# Altium schematic sheet Microcontroller.SchDoc(Microcontroller)
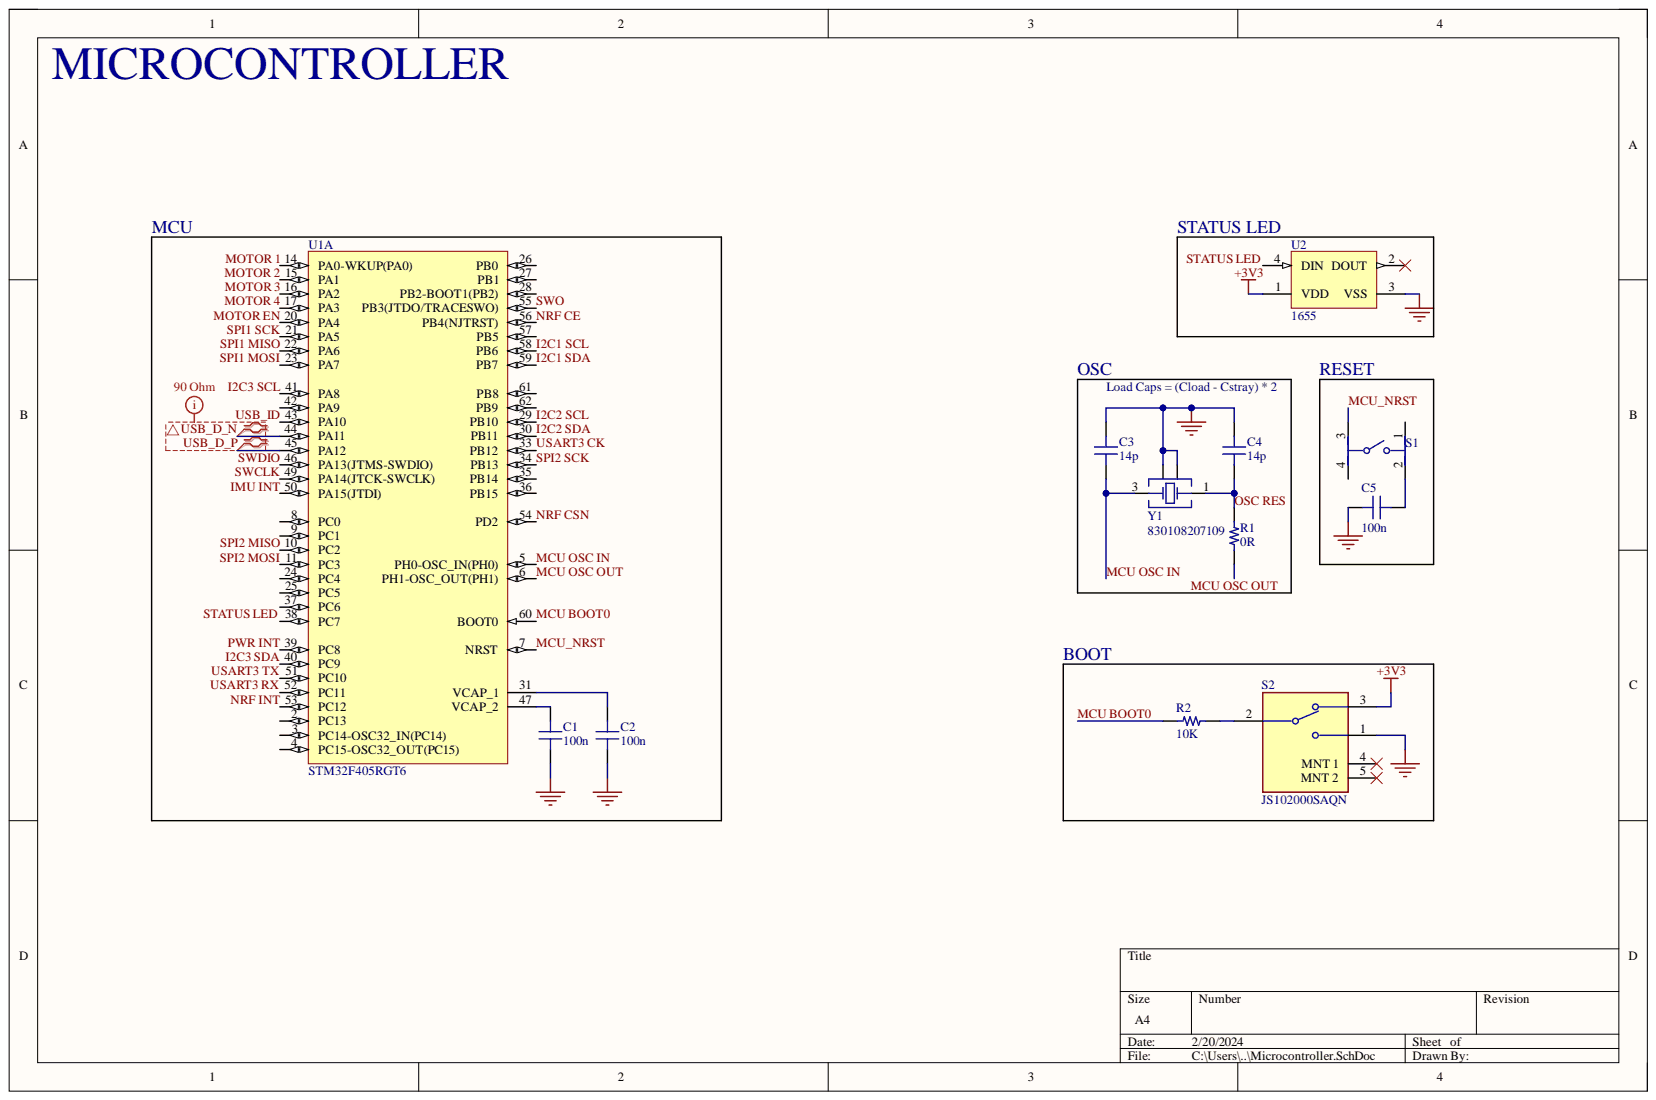
[diagram p0: Microcontroller.SchDoc]

## Parts
C1  100n  [CAP 0402_1005]
C2  100n  [CAP 0402_1005]
C3  14p  [CAP 0402_1005]
C4  14p  [CAP 0402_1005]
C5  100n  [CAP 0402_1005]
R1  0R  [RES 0402_1005]
R2  10K  [RES 0402_1005]
S1  PTS645SM43SMTR92LFS  [FP-PTS645SM43SMTR92LFS-MFG]  (value not drawn)
S2  JS102000SAQN  [FP-JS102000SAQN-MFG]
U1  STM32F405RGT6  [STM-LQFP64_M]  (part A)
U2  1655  [ADA-RGB-1655_V]
Y1  830108207109  [WE-XTAL_CFPX-180]

## Nets
+3V3: S2.3 U2.1(VDD)
GND: C1.1 C2.1 C3.2 C4.2 C5.2 S2.1 U2.3(VSS) Y1.2 Y1.4
I2C1 SCL: U1.58(PB6)
I2C1 SDA: U1.59(PB7)
I2C2 SCL: U1.29(PB10)
I2C2 SDA: U1.30(PB11)
I2C3 SCL: U1.41(PA8)
I2C3 SDA: U1.40(PC9)
IMU INT: U1.50(PA15(JTDI))
MCU BOOT0: R2.1 U1.60(BOOT0)
MCU OSC IN: C3.1 U1.5(PH0-OSC_IN(PH0)) Y1.3
MCU OSC OUT: R1.1 U1.6(PH1-OSC_OUT(PH1))
MCU_NRST: S1.3 U1.7(NRST)
MOTOR 1: U1.14(PA0-WKUP(PA0))
MOTOR 2: U1.15(PA1)
MOTOR 3: U1.16(PA2)
MOTOR 4: U1.17(PA3)
MOTOR EN: U1.20(PA4)
NRF CE: U1.56(PB4(NJTRST))
NRF CSN: U1.54(PD2)
NRF INT: U1.53(PC12)
OSC RES: C4.1 R1.2 Y1.1
PWR INT: U1.39(PC8)
SPI1 MISO: U1.22(PA6)
SPI1 MOSI: U1.23(PA7)
SPI1 SCK: U1.21(PA5)
SPI2 MISO: U1.10(PC2)
SPI2 MOSI: U1.11(PC3)
SPI2 SCK: U1.34(PB13)
STATUS LED: U1.38(PC7) U2.4(DIN)
SWCLK: U1.49(PA14(JTCK-SWCLK))
SWDIO: U1.46(PA13(JTMS-SWDIO))
SWO: U1.55(PB3(JTDO/TRACESWO))
USART3 CK: U1.33(PB12)
USART3 RX: U1.52(PC11)
USART3 TX: U1.51(PC10)
USB_D_N: U1.44(PA11)
USB_D_P: U1.45(PA12)
USB_ID: U1.43(PA10)
NetC1_2: C1.2 U1.47(VCAP_2)
NetC2_2: C2.2 U1.31(VCAP_1)
NetC5_1: C5.1 S1.2
NetR2_2: R2.2 S2.2

Not connected: S1 1 4; S2 4(MNT_1) 5(MNT_2); U1 2(PC13) 3(PC14-OSC32_IN(PC14)) 4(PC15-OSC32_OUT(PC15)) 8(PC0) 9(PC1) 24(PC4) 25(PC5) 26(PB0) 27(PB1) 28(PB2-BOOT1(PB2)) 35(PB14) 36(PB15) 37(PC6) 42(PA9) 57(PB5) 61(PB8) 62(PB9); U2 2(DOUT)

## Library data of the parts
C1 C2 = CL05B104KP5VPNC: CAP CER 100NF 10V X7R 0402; manufacturer: Samsung Electro-Mechanics; Capacitance: 0.1 µF; Database Table Name: Capacitors - Ceramic - 0402; Tolerance: ±10%; Applications: Automotive; Component Kind: Standard; componentlink1description: Datasheet; componentlink1url: https://mm.digikey.com/Volume0/opasdata/d220001/medias/docus/5458/CL05B104KP5VPNC_Spec.pdf; componentlink2description: DigiKey Link; componentlink2url: https://www.digikey.com/en/products/detail/samsung-electro-mechanics/CL05B104KP5VPNC/20498482; Device Package: 0402 (1005 Metric); lastupdated: 29/01/2024 8:03:07 PM; Library Name: Celestial Altium Library - altium_library.DbLib; Lifecycle Status: Active; Minimum Order: 1; Mounting Type: Surface Mount, MLCC; Operating Temperature: -55°C ~ 125°C; Package / Case: 0402 (1005 Metric); packaging: TapeAndReel; Part Number: CL05B104KP5VPNC; partid: 56690; price: 0.01; Ratings: AEC-Q200; series: CL; Size / Dimension: 0.039" L x 0.020" W (1.00mm x 0.50mm); Supplier 1: DigiKey; Supplier Part Number 1: 1276-CL05B104KP5VPNCCT-ND; Temperature Coefficient: X7R; Thickness (Max): 0.022" (0.55mm); Value: 100n; Voltage - Rated: 10V
C3 C4 = GJM1555C1H140GB01D: CAP CER 14PF 50V C0G/NP0 0402; Database Table Name: Capacitors - Ceramic - 0402; Component Kind: Standard; Library Name: Celestial Altium Library - altium_library.DbLib; Part Number: GJM1555C1H140GB01D
C5 = CL05B104KP5VPNC: CAP CER 100NF 10V X7R 0402; Database Table Name: Capacitors - Ceramic - 0402; Component Kind: Standard; Library Name: Celestial Altium Library - altium_library.DbLib; Part Number: CL05B104KP5VPNC
R1 = 5112: 0402 JUMPER ON TAPE AND REEL; Database Table Name: Resistors - Surface Mount - 0402; Component Kind: Standard; Library Name: Celestial Altium Library - altium_library.DbLib; Part Number: 5112
R2 = RP73PF1E10KBTD: RES 10K OHM 0.1% 1/10W 0402; Database Table Name: Resistors - Surface Mount - 0402; Component Kind: Standard; Library Name: Celestial Altium Library - altium_library.DbLib; Part Number: RP73PF1E10KBTD
S1 = CMP-2000-05160-2: SWITCH TACTILE SPST-NO 0.05A 12V; Actuator Length: 0.85mm; Actuator Material: Nylon; Actuator Type: Standard; Contact Resistance: 100mR; Current Rating: 50mA; Illumination Type: Non-Illuminated; Mechanical Life: 100000Cycles; Operating Force: 160gf; Switch Function: Off-Mom; Throw Configuration: SPST; Case/Package: SMD/SMT; Color: Black; Component Kind: Standard; Contact Material: Silver; Contact Plating: Silver; Depth: 9mm; Lead Free: Lead Free; Length: 6mm; Library Name: Altium Content Vault; Max Current Rating: 50mA; Max Operating Temperature: 60°C; Max Voltage Rating (DC): 12V; Min Operating Temperature: -20°C; Mount: Surface Mount; Orientation: Straight; Package Quantity: 1; Packaging: Tape and Reel; REACH SVHC: No SVHC; Termination: Gull Wing; Voltage Rating (DC): 12V
S2 = CMP-10038-000025-1: SWITCH SLIDE SPDT 1MA 5V; Actuator Color: Black; Actuator Length: 1.5mm; Actuator Material: Nylon; Actuator Type: Standard; Contact Resistance: 70mR; Electrical Life: 15000Cycles; Switch Function: On-On; Case/Package: SMD/SMT; Component Kind: Standard; Contact Current Rating: 1mA; Contact Material: Copper Alloy; Contact Plating: Silver; Current Rating: 1mA; Depth: 4.82mm; Flammability Rating: UL94 V-2; Housing Material: Nylon; Length: 7.8mm; Library Name: Altium Content Vault; Max Current Rating: 1mA; Max Operating Temperature: 85°C; Max Voltage Rating (DC): 5V; Min Operating Temperature: -20°C; Mount: Surface Mount; Orientation: Right Angle; Package Quantity: 1; Packaging: Tape and Reel; Radiation Hardening: No; REACH SVHC: No SVHC; RoHS Compliant: Yes; Series: JS; Switch Travel: 1.5mm; Termination: Gull Wing; Throw Configuration: SPDT; Voltage Rating (DC): 5V
U1 = CMP-0243-00019-3: ARM Cortex-M4 32-bit MCU+FPU, 210 DMIPS, 1024 kB Flash, 192 kB Internal RAM, 51 I/Os, 64-pin LQFP, -40 to 85 degC, Tray; Manufacturer: STMicroelectronics; D/A Converter: 2x12-bit; FLASH Size (Prog) (kB): 1024; I/Os (High Current): 51; Internal RAM Size (kB): 192; Operating Frequency(F) (Processor speed) (MHz): 168; Supply Voltage(Vcc) max (V): 3.6; Supply Voltage(Vcc) min (V): 1.8; Component Kind: Standard; ComponentLink1Description: Manufacturer URL; ComponentLink1URL: http://www.st.com/web/en/home.html; ComponentLink2Description: Datasheet; ComponentLink2URL: http://www.st.com/st-web-ui/static/active/en/resource/technical/document/datasheet/DM00037051.pdf; ComponentLink3Description: Package Specification; ComponentLink3URL: http://www.st.com/st-web-ui/static/active/en/resource/technical/document/datasheet/DM00037051.pdf; Core: ARM Cortex-M4; DatasheetVersion: Rev. 4; Library Name: Altium Content Vault; Mounting Technology: Surface Mount; PackageDescription: 64-Pin Low Profile Quad Flat Package, 10 x 10 mm Body, 0.5 mm Pitch; PackageReference: LQFP64; PackageVersion: Rev. 4, 06/2013; Packing: Tray; PartNumber: STM32F405RGT6
U2 = CMP-2000-05026-1: Intelligent Control LED Integrated Light Source, 3.5 to 5.3 V, -25 to 80 degC, 4-Pin SMD, RoHS, Tape and Reel; Manufacturer: Adafruit-Industries-LLC; Configuration: Discrete; Number of LEDs: 10; Utilized LED: WS2812(5050); Category: Optoelectronics; Color: Red,Green,Blue(RGB); Component Kind: Standard; Controller Type: -; Datasheet URL: http://datasheet.octopart.com/ADA1655-Adafruit-Industries-datasheet-32028139.pdf; Datasheet Version: May-13; Family: Addressable,Specialty; Interface: -; Library Name: Altium Content Vault; Manufacturer URL: https://www.adafruit.com/; Mounting Type: SurfaceMount; Package Description: 4-Pin Surface Mount Device, Body 5 x 5 mm; Package Reference: 1655; Series: Neopixel; Size / Dimension: 5.00mmLx5.00mmW
Y1 = CMP-03913-116855757-1: 830108207109 WE-XTAL Quartz Crystal; Frequency: 16MHz; Component Kind: Standard; Height: 800µm; Length: 3.2mm; Library Name: Altium Content Vault; Max Operating Temperature: 85°C; Min Operating Temperature: -40°C; NexarPartId: 116855757; Width: 2.5mm
